# Altium schematic sheet Sheet1.SchDoc(Sheet1)
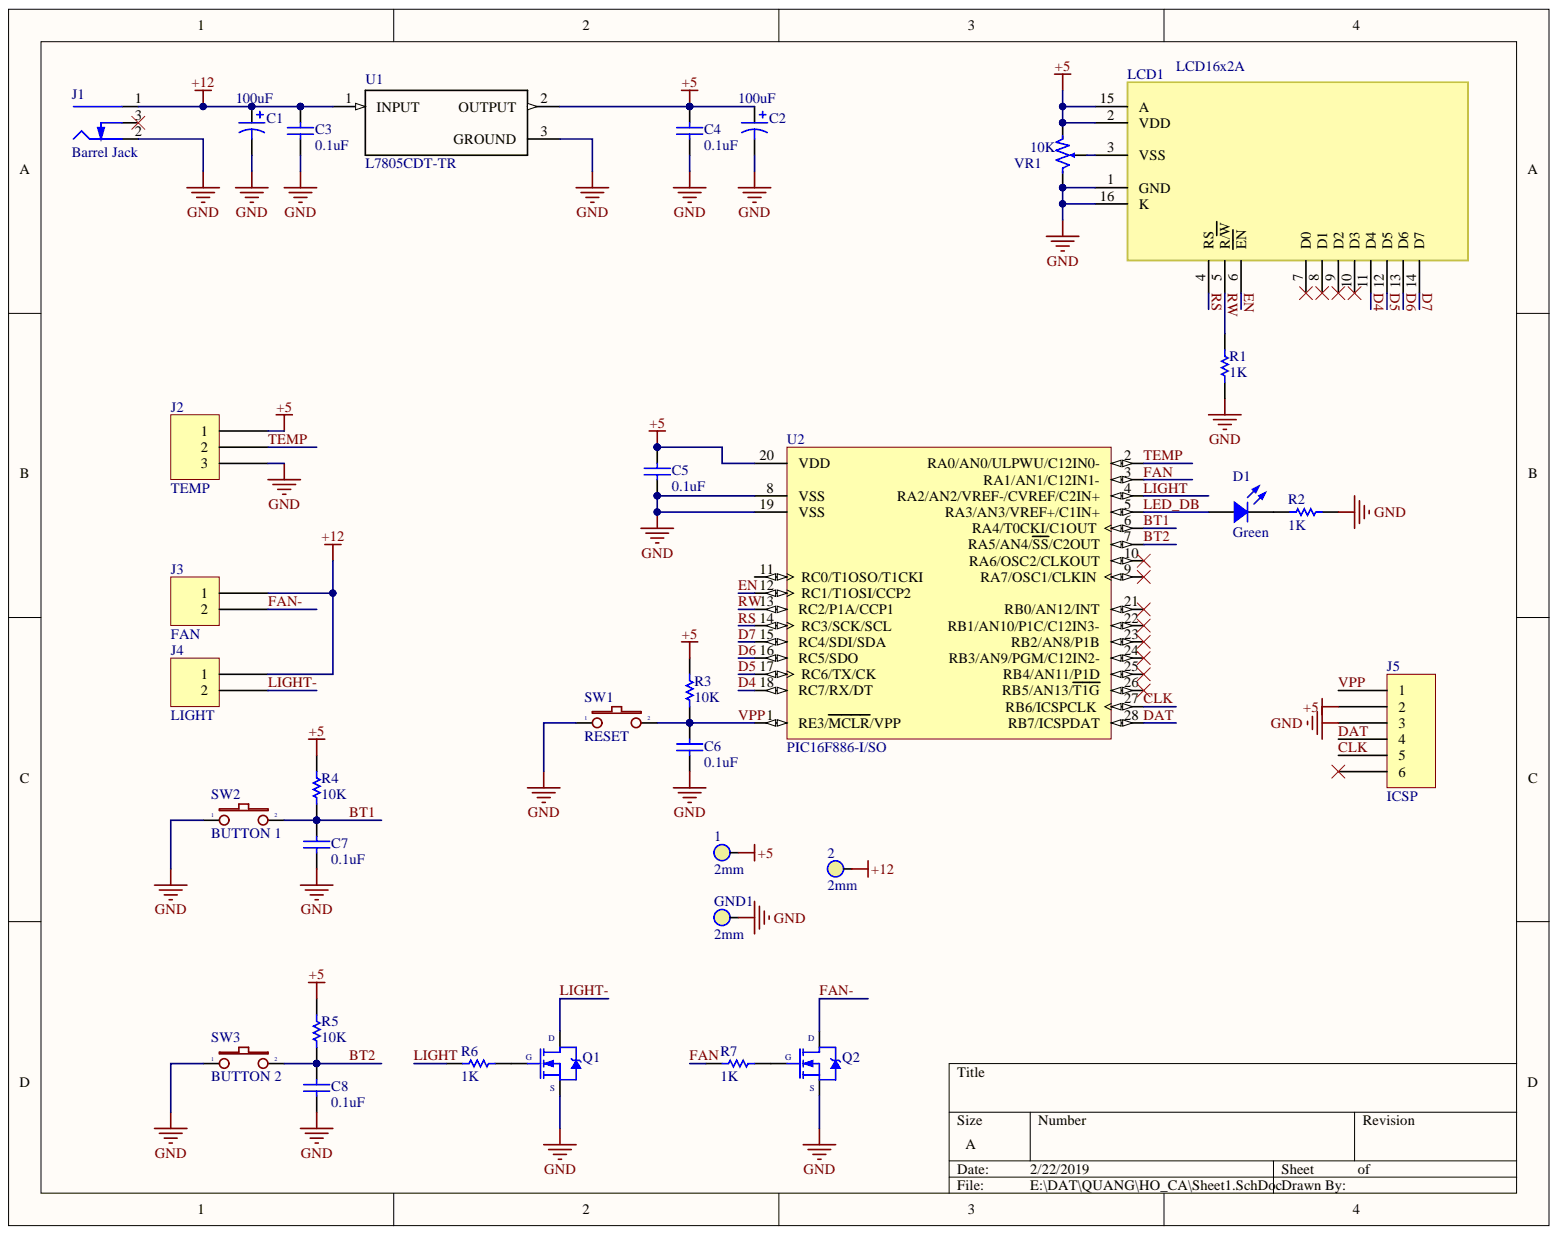
[diagram p0: Sheet1.SchDoc]

## Parts
1  2mm  [Test Point 2mm]
2  2mm  [Test Point 2mm]
C1  value 100uF  [SMD Size B (Dia 4mm)]  (hidden comment: ALUM)
C2  value 100uF  [SMD Size B (Dia 4mm)]  (hidden comment: ALUM)
C3  value 0.1uF  [CAP 0805 (2012 Metric)]  (hidden comment: CER)
C4  value 0.1uF  [CAP 0805 (2012 Metric)]  (hidden comment: CER)
C5  value 0.1uF  [CAP 0805 (2012 Metric)]  (hidden comment: CER)
C6  value 0.1uF  [CAP 0805 (2012 Metric)]  (hidden comment: CER)
C7  value 0.1uF  [CAP 0805 (2012 Metric)]  (hidden comment: CER)
C8  value 0.1uF  [CAP 0805 (2012 Metric)]  (hidden comment: CER)
D1  Green  [LED 0805/2012 BLUE]
GND1  2mm  [Test Point 2mm]
J1  Barrel Jack  [4840.2211]
J2  TEMP  [JST XH B3B-XH-A 2.5]
J3  FAN  [JST XH B2B-XH-A 2.5]
J4  LIGHT  [JST XH B2B-XH-A 2.5]
J5  ICSP  [JST XH B6B-XH-A 2.5]
LCD1  LCD16x2A  [LCD16X2]
Q1  IRFR3708TRPBF  [TO-252-3(DPAK) - No via]  (value not drawn)
Q2  IRFR3708TRPBF  [TO-252-3(DPAK) - No via]  (value not drawn)
R1  value 1K  [RES 0805 (2012 Metric)]  (hidden comment: Res Semi)
R2  value 1K  [RES 0805 (2012 Metric)]  (hidden comment: Res Semi)
R3  value 10K  [RES 0805 (2012 Metric)]  (hidden comment: Res Semi)
R4  value 10K  [RES 0805 (2012 Metric)]  (hidden comment: Res Semi)
R5  value 10K  [RES 0805 (2012 Metric)]  (hidden comment: Res Semi)
R6  value 1K  [RES 0805 (2012 Metric)]  (hidden comment: Res Semi)
R7  value 1K  [RES 0805 (2012 Metric)]  (hidden comment: Res Semi)
SW1  RESET  [TE FSMSMTR]
SW2  BUTTON 1  [TE FSMSMTR]
SW3  BUTTON 2  [TE FSMSMTR]
U1  L7805CDT-TR  [*VREG_L7805CDT-TR]
U2  PIC16F886-I/SO  [SOIC-SO28_L]
VR1  10K  [RESISTOR POT 2]

## Nets
+5: 1.1 C2.1 C4.2 C5.1 J2.1 J5.2 LCD1.2(VDD) LCD1.15(A) R3.2 R4.2 R5.2 U1.2 U2.20(VDD) VR1.1
+12: 2.1 C1.1 C3.2 J1.1 J3.1 J4.1 U1.1
GND: C1.2 C2.2 C3.1 C4.1 C5.2 C6.1 C7.1 C8.1 GND1.1 J1.2 J2.3 J5.3 LCD1.1(GND) LCD1.16(K) Q1.3 Q2.3 R1.1 R2.1 SW1.1 SW2.1 SW3.1 U1.3 U2.8(VSS) U2.19(VSS) VR1.3
BT1: C7.2 R4.1 SW2.2 U2.6(RA4/T0CKI/C1OUT)
BT2: C8.2 R5.1 SW3.2 U2.7(RA5/AN4/SS/C2OUT)
CLK: J5.5 U2.27(RB6/ICSPCLK)
D4: LCD1.11(D4) U2.18(RC7/RX/DT)
D5: LCD1.12(D5) U2.17(RC6/TX/CK)
D6: LCD1.13(D6) U2.16(RC5/SDO)
D7: LCD1.14(D7) U2.15(RC4/SDI/SDA)
DAT: J5.4 U2.28(RB7/ICSPDAT)
EN: LCD1.6(EN) U2.12(RC1/T1OSI/CCP2)
FAN: R7.2 U2.3(RA1/AN1/C12IN1-)
FAN-: J3.2 Q2.2
LED_DB: D1.1 U2.5(RA3/AN3/VREF+/C1IN+)
LIGHT: R6.2 U2.4(RA2/AN2/VREF-/CVREF/C2IN+)
LIGHT-: J4.2 Q1.2
RS: LCD1.4(RS) U2.14(RC3/SCK/SCL)
RW: LCD1.5(R/W) R1.2 U2.13(RC2/P1A/CCP1)
TEMP: J2.2 U2.2(RA0/AN0/ULPWU/C12IN0-)
VPP: C6.2 J5.1 R3.1 SW1.2 U2.1(RE3/MCLR/VPP)
NetD1_2: D1.2 R2.2
NetLCD1_3: LCD1.3(VSS) VR1.2
NetQ1_1: Q1.1 R6.1
NetQ2_1: Q2.1 R7.1

Not connected: J1 3; J5 6; LCD1 7(D0) 8(D1) 9(D2) 10(D3); U2 9(RA7/OSC1/CLKIN) 10(RA6/OSC2/CLKOUT) 11(RC0/T1OSO/T1CKI) 21(RB0/AN12/INT) 22(RB1/AN10/P1C/C12IN3-) 23(RB2/AN8/P1B) 24(RB3/AN9/PGM/C12IN2-) 25(RB4/AN11/P1D) 26(RB5/AN13/T1G)

## Library data of the parts
1 2 GND1 = Test Point: Library Name: Smart LED.SchLib; Description: Test Point; Component Kind: Standard
C1 C2 = ALUM: Capacitor ALUM; Published: 8-Jun-2000; PackageReference: POLAR0.8; PackageDescription: Polarized Capacitor; 2 Leads; Library Name: Capacitors.IntLib; LatestRevisionNote: Re-released for DXP Platform.; LatestRevisionDate: 17-Jul-2002; Component Kind: Standard; Publisher: Altium Limited
C3-C8 = CER: Capacitor CER; Published: 8-Jun-2000; PackageReference: RAD-0.3; PackageDescription: Radial Cap, Thru-Hole; 2 Leads; 0.3 in Pin Spacing; Library Name: Capacitors.IntLib; LatestRevisionNote: Re-released for DXP Platform.; LatestRevisionDate: 17-Jul-2002; Component Kind: Standard; Publisher: Altium Limited
D1 = LED: Chip LED; Value: Green; Library Name: Diodes.IntLib; Component Kind: Standard
J1 = Barrel Connector: Library Name: Connectors.IntLib; Component Kind: Standard
J2 = 3 Pin: Library Name: Connectors.IntLib; Component Kind: Standard
J3 J4 = 2 Pin: Library Name: Connectors.IntLib; Component Kind: Standard
J5 = 6 Pin: Library Name: Connectors.IntLib; Component Kind: Standard
LCD1 = LCD16x2: LCD dipslay 16 char, 2 line; Value: LCD16x2A; Component Kind: Standard; Library Name: syhaunguyen.IntLib
Q1 Q2 = IRFR3708TRPBF: MOSFET N-CH 30V 61A DPAK; Library Name: Smart LED.SchLib; ComponentLink1URL: https://thegioiic.com/products/irfr3708trpbf; ComponentLink1Description: thegioiic.com; Component Kind: Standard
R1-R7 = Res Semi: Semiconductor Resistor; Published: 8-Jun-2000; PackageReference: AXIAL-0.5; PackageDescription: Axial Device, Thru-Hole; 2 Leads; 0.5 in Pin Spacing; LatestRevisionNote: Re-released for DXP Platform.; LatestRevisionDate: 17-Jul-2002; Component Kind: Standard; Publisher: Altium Limited; Library Name: Resitor.IntLib
SW1-SW3 = SW2: Switch button 2 pin; Value: SW chip 2 pin; Component Kind: Standard; Library Name: syhaunguyen.IntLib
U1 = L7805CDT-TR: L7805 Series 4.8 V 1.5 A Three Terminal Positive Voltage Regulator - TO-252-3; MF: STMicroelectronics; SnapEDA_Link: https://www.snapeda.com/parts/L7805CDT-TR/STMicroelectronics/view-part/?ref=snap; Price: None; Package: TO-252 STMicroelectronics; MP: L7805CDT-TR; Library Name: L7805CDT-TR.SchLib; Component Kind: Standard; Availability: Unavailable
U2 = PIC16F886-I/SO: Flash-Based, 8-Bit CMOS Microcontroller with nanoWatt Technology, 8K (x14-Bit words) Program Memory, 624 Bytes Data Memory, 24 I/O pins, 28-Pin SOIC, Standard VDD Range, Industrial Temperature; Supplier Part Number 2: 1439538; Supplier Part Number 1: PIC16F886-I/SO-ND; Supplier 2: Farnell; Supplier 1: Digi-Key; Published: 29-Jan-2009; PackageVersion: revBB, Aug-2009; PackageReference: SOIC-SO28; PackageDescription: 28-Lead Plastic Small Outline (SO) - Wide 7.50mm Body [SOIC]; Library Name: Microchip Microcontroller 8-Bit PIC16.SchLib; LatestRevisionNote: Updated datasheet to version revF, Apr-2009.; LatestRevisionDate: 02-Dec-2009; DatasheetVersion: revF, Apr-2009; ComponentLink3URL: http://www.microchip.com/; ComponentLink3Description: Microchip.com Home; ComponentLink2URL: http://www.microchip.com/stellent/groups/techpub_sg/documents/packagingspec/en012702.pdf; ComponentLink2Description: Packaging Specification; ComponentLink1URL: http://ww1.microchip.com/downloads/en/DeviceDoc/41291F.pdf; ComponentLink1Description: Datasheet; Component Kind: Standard; Code_JEDEC: MS-013; Publisher: Altium Limited
VR1 = Resistor Var: Resistor Var Variable or Adjustable Resistor; Value: VR 10K; Component Kind: Standard; Library Name: TanMy.SchLib
